# Altium schematic sheet Power and CAN Bus.SchDoc
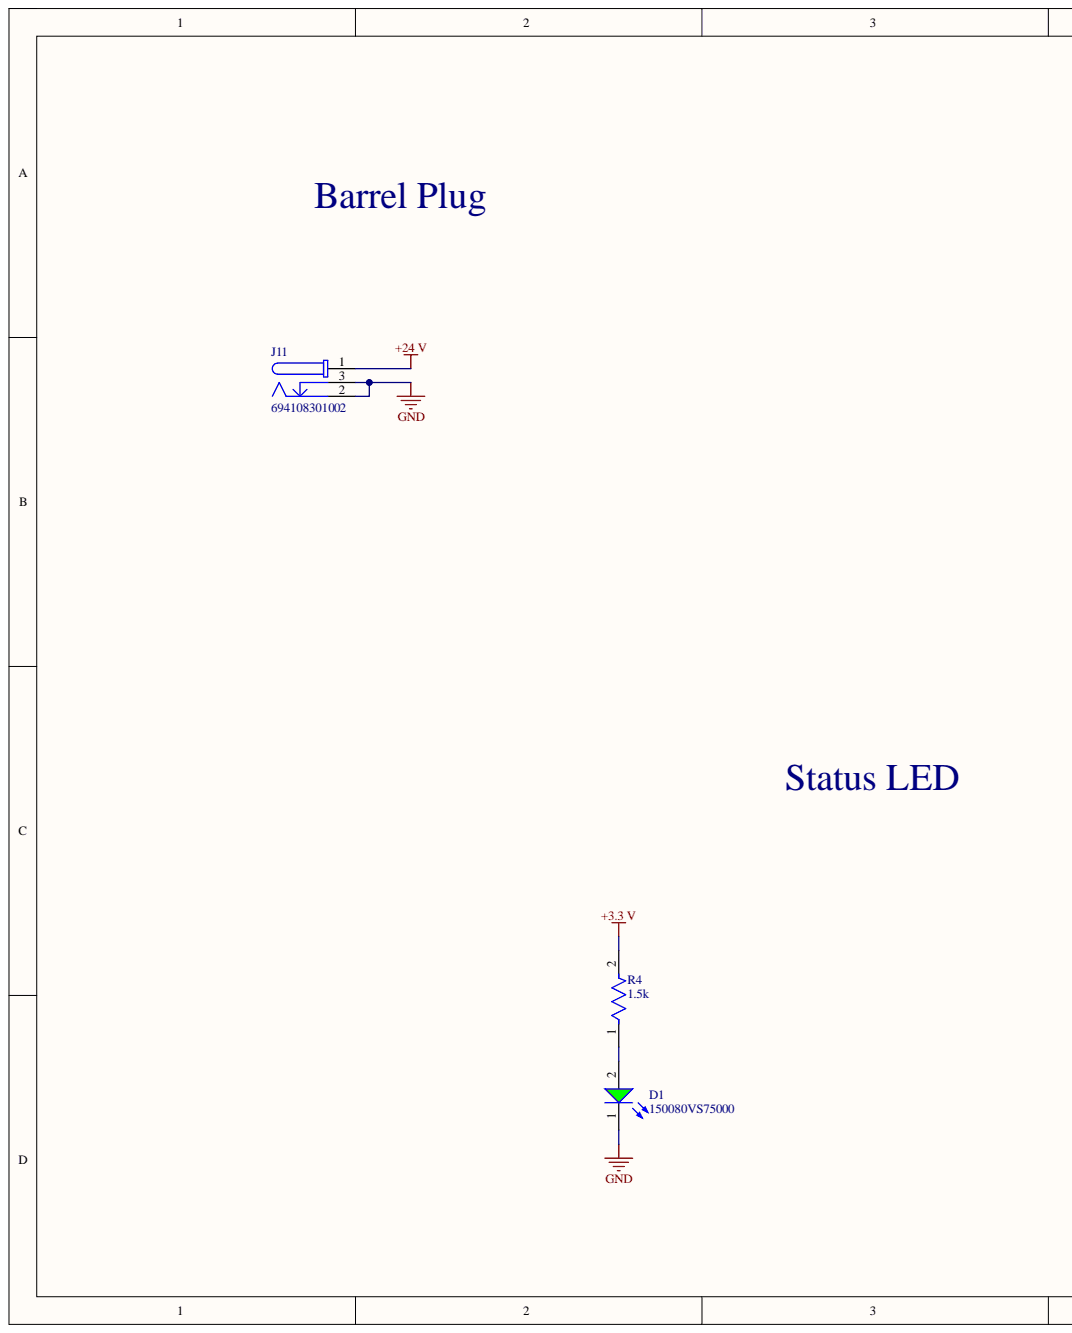
[diagram p0: Power and CAN Bus.SchDoc, left]
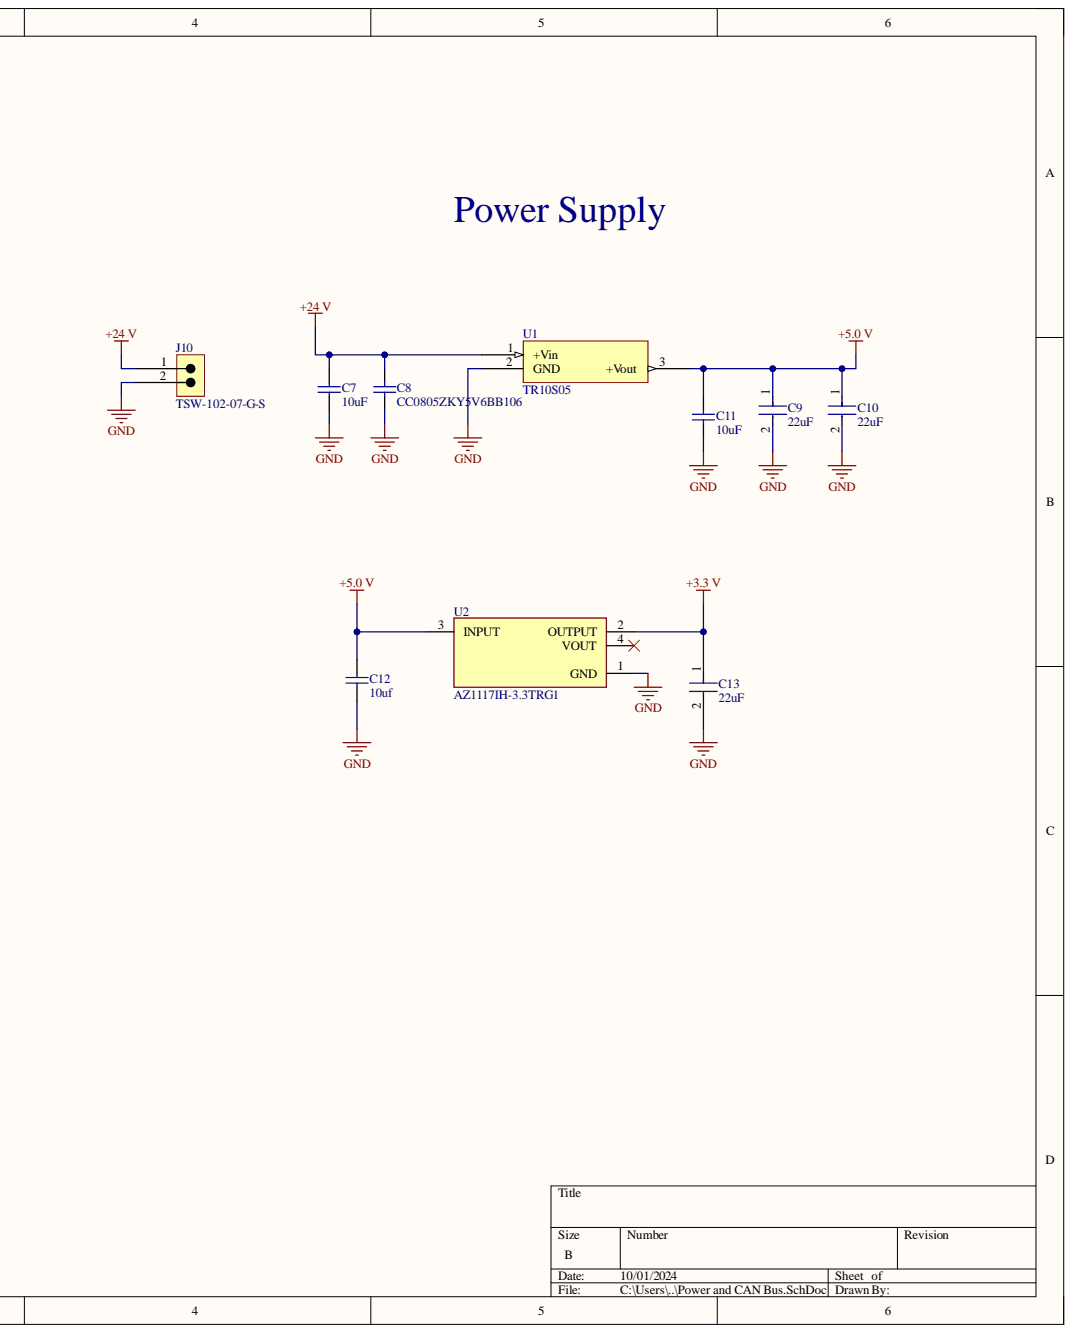
[diagram p1: Power and CAN Bus.SchDoc, right]

## Parts
C7  10uF  [CC0805ZKY5V6BB106-Footprint-1]
C8  CC0805ZKY5V6BB106  value 10uF  [CC0805ZKY5V6BB106-Footprint-1]
C9  22uF  [FP-GMC21R-IPC_B]
C10  22uF  [FP-GMC21R-IPC_B]
C11  10uF  [CC0805ZKY5V6BB106-Footprint-1]
C12  10uf  [CC0805ZKY5V6BB106-Footprint-1]
C13  22uF  [FP-GMC21R-IPC_B]
D1  150080VS75000  [WL-SMCW_0805_150080xx75000]
J10  TSW-102-07-G-S  [FP-TSW-102-07-G-S-MFG]
J11  694108301002  [694108301002]
R4  1.5k  [FP-RT0805-IPC_A]
U1  TR10S05  [TR10S05-Footprint-1]
U2  AZ1117IH-3.3TRG1  [FP-SOT223-IPC_B]

## Nets
+3.3 V: C13.1 R4.2 U2.2(OUTPUT)
+5.0 V: C9.1 C10.1 C11.2 C12.2 U1.3 U2.3(INPUT)
+24 V: C7.2 C8.2 J10.1 J11.1 U1.1
GND: C7.1 C8.1 C9.2 C10.2 C11.1 C12.1 C13.2 D1.1 J10.2 J11.2 J11.3 U1.2 U2.1(GND)
NetD1_2: D1.2 R4.1

Not connected: U2 4(VOUT)

## Library data of the parts
C7 C8 C11 C12 = CMP-00010-2: Ceramic Capacitor, Multilayer, Ceramic, 10V, 80% +Tol, 20% -Tol, Y5V, -82/+22% TC, 10uF, Surface Mount, 0805; Multilayer: Yes; Capacitor Type: Ceramic; Case/Package: 0805; Component Kind: Standard; Dielectric Material: Ceramic; Height: 1.25mm; JESD-609 Code: e3; Length: 2mm; Library Name: C0514760 C0514760; Max Operating Temperature: 85°C; Min Operating Temperature: -30°C; Mounting Technology: Surface Mount; Package Shape: Rectangular; Package Style: SMTMeter; Packaging: Tape and Reel; Pins: 2; Rated DC Voltage (URdc): 10V; Reach SVHC Compliant: Yes; RoHS Compliant: Yes; Temperature Characteristics Code: Y5V; Terminal Finish: Nickel; Termination: Wraparound; Tolerance  (fill in as +-): 20%; Tolerance (fill in as +-): 80%; Width: 1.25mm
  C7 C11 C12: Value: 10uF
C9 C10 C13 = CMP-00027-1: Ceramic Capacitor, Multilayer, Ceramic, 35V, 20% +Tol, 20% -Tol, X5R, 15% TC, 22uF, Surface Mount, 0805; Component Kind: Standard; Library Name: C0514760 C0514760
D1 = CMP-00021-1: Single Color LED, Bright Green, Water Clear, 1.4mm; Channels: 1; Forward Current: 30mA; Luminous Intensity-Nom: 40mcd; Overall Height: 0.7mm; Shape: Rectangular; Viewing Angle: 140°; Color: Green; Component Kind: Standard; Lens Style: WATER CLEAR; Library Name: C0514760 C0514760; Max Operating Temperature: 85°C; Min Operating Temperature: -40°C; Mounting Technology: Surface Mount; Optoelectronic Device Type: SINGLE COLOR LED; Packaging: Tape and Reel; Pins: 2; Reach SVHC Compliant: Yes; RoHS Compliant: Yes; Size: 1.4mm; Terminal Pitch: 2.1mm
J10 = CMP-00020-2: Board Connector, 2 Contact(s), 1 Row(s), Male, Straight, 0.1 inch Pitch, Solder Terminal, Black Insulator; Additional Feature: E.L.P.; Body/Shell Style: RECEPTACLE; Contact Pattern: RECTANGULAR; Contact Style: SQ PIN-SKT; DIN Conformance: No; Mating Information: MULTIPLE MATING PARTS AVAILABLE; Number Of Connectors: 1; Number of Contacts: 2; Option: General Purpose; Plating Thickness: 10uin; Reference Standard: UL; Reliability: COMMERCIAL; Body Breadth: 0.1inch; Body Depth: 0.1inch; Component Kind: Standard; Connector Type: BOARD CONNECTOR; Contact Finish - Mating: GOLD (10) OVER NICKEL (50); Contact Finish - Termination: Gold (Au) - with Nickel (Ni) barrier; Contact Gender: Male; Contact Material: Bronze; Filter Feature: No; Flammability Rating: UL94 V-0; IEC Conformance: No; Insulation Color: Black; Insulation Material: Glass; JESD-609 Code: e4; Library Name: C0514760 C0514760; Mating Contact Pitch: 0.1inch; MIL Conformance: No; Mixed Contacts: No; Mounting Style: STRAIGHT; Mounting Technology: Board; Number Of PCB Rows: 1; Number of Rows Loaded: 1; PCB Contact Pattern: RECTANGULAR; Reach SVHC Compliant: Yes; RoHS Compliant: Yes; Terminal Length: 0.1inch; Terminal Pitch: 2.54mm; Termination: Solder
J11 = CMP-002-00075-2: DC Power Jack Connector WR-DC, Male, Right Angled, THT, 5 A, 24 V; Body Color: Black; Connector Type: Power,Jack; Current Rating: 5A; Insulation Resistance: 100MR; Number of Contacts: 3; Operating Supply Voltage: 24V; Pin Diameter: 2.5mm; Shielding: Unshielded; Voltage Rating (DC): 20V; Color: Black; Component Kind: Standard; Contact Gender: Female; Contact Material: Copper Alloy; Contact Plating: Tin; Flammability Rating: UL94 V-0; Gender: Male; Height: 11mm; Housing Material: Polyamide; Library Name: C0514760 C0514760; Max Operating Temperature: 85°C; Min Operating Temperature: -40°C; Mounting Technology: Through Hole; Package Quantity: 500; Packaging: Bulk; Reach SVHC Compliant: Unknown; RoHS Compliant: Yes; Series: WR-DC; Termination: Through Hole; Voltage Rating: 24V
R4 = CMP-00013-1: Fixed Resistor, Thin Film, 0.125W, 1500ohm, 150V, 1% +/-Tol, 50ppm/Cel, Surface Mount, 0805; Additional Feature: HIGH PRECISION; Package Height: 0.5mm; Package Length: 2mm; Package Width: 1.25mm; Power: 125mW; Rated Temperature: 70°C; Temperature Coefficient: 50ppm/°C; Value: 1.5kR; Case/Package: 0805; Component Kind: Standard; Construction: Chip; Library Name: C0514760 C0514760; Max Operating Temperature: 155°C; Min Operating Temperature: -55°C; Mounting Technology: Surface Mount; Package Style: SMTMeter; Packaging: Tape and Reel; Pins: 2; Reach SVHC Compliant: Yes; Resistor Type: FIXED RESISTOR; RoHS Compliant: Yes; Technology: THIN FILM; Termination: Wraparound; Tolerance: 1%; Working Voltage: 150V
U1 = CMP-019-00050-2: DC-DC Regulated Power Supply Module; Component Kind: Standard; Library Name: C0514760 C0514760; Reach SVHC Compliant: Yes; RoHS Compliant: Yes; Throw Configuration: DC-DC REGULATED POWER SUPPLY MODULE
U2 = CMP-00028-1: Fixed Positive LDO Regulator, 3.3V, 1.3V Dropout, PDSO3; Channels: 1; Max Supply Voltage: 15V; Output Current1-Max: 800mA; Output Voltage1-Max: 3.365V; Output Voltage1-Min: 3.235V; Package Body Material: Plastic; Regulator Type: FIXED POSITIVE SINGLE OUTPUT LDO REGULATOR; Case/Package: SOP; Component Kind: Standard; Dropout Voltage1-Max: 1.3V; Height - Seated (Max): 1.8mm; JESD-30 Code: R-PDSO-G3; Length: 6.5mm; Library Name: C0514760 C0514760; Operating Temperature, TJ-Max: 125°C; Operating Temperature, TJ-Min: -40°C; Output Voltage1-Nom: 3.3V; Package Shape: Rectangular; Package Style: SMALL OUTLINEMeter; Pins: 3; Reach SVHC Compliant: Yes; RoHS Compliant: Yes; Surface Mount: Yes; Terminal Form: Gull Wing; Terminal Pitch: 2.3mm; Terminal Position: DUAL; Width: 3.5mm
